# Altium schematic sheet Amplifier.SchDoc(U_Amplifier)
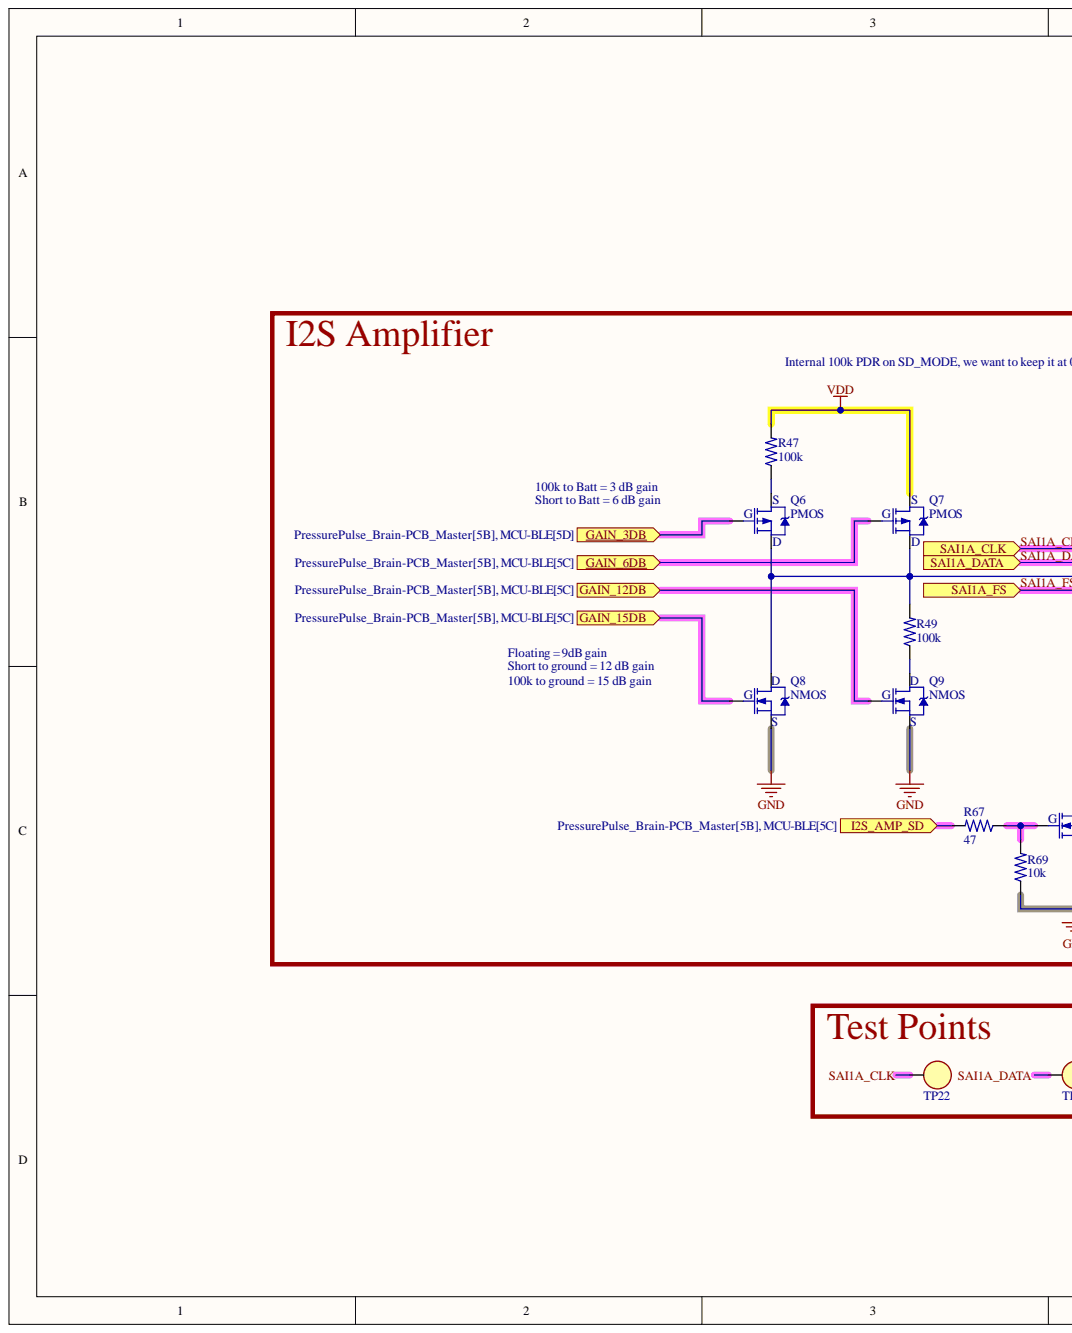
[diagram p0: Amplifier.SchDoc, left]
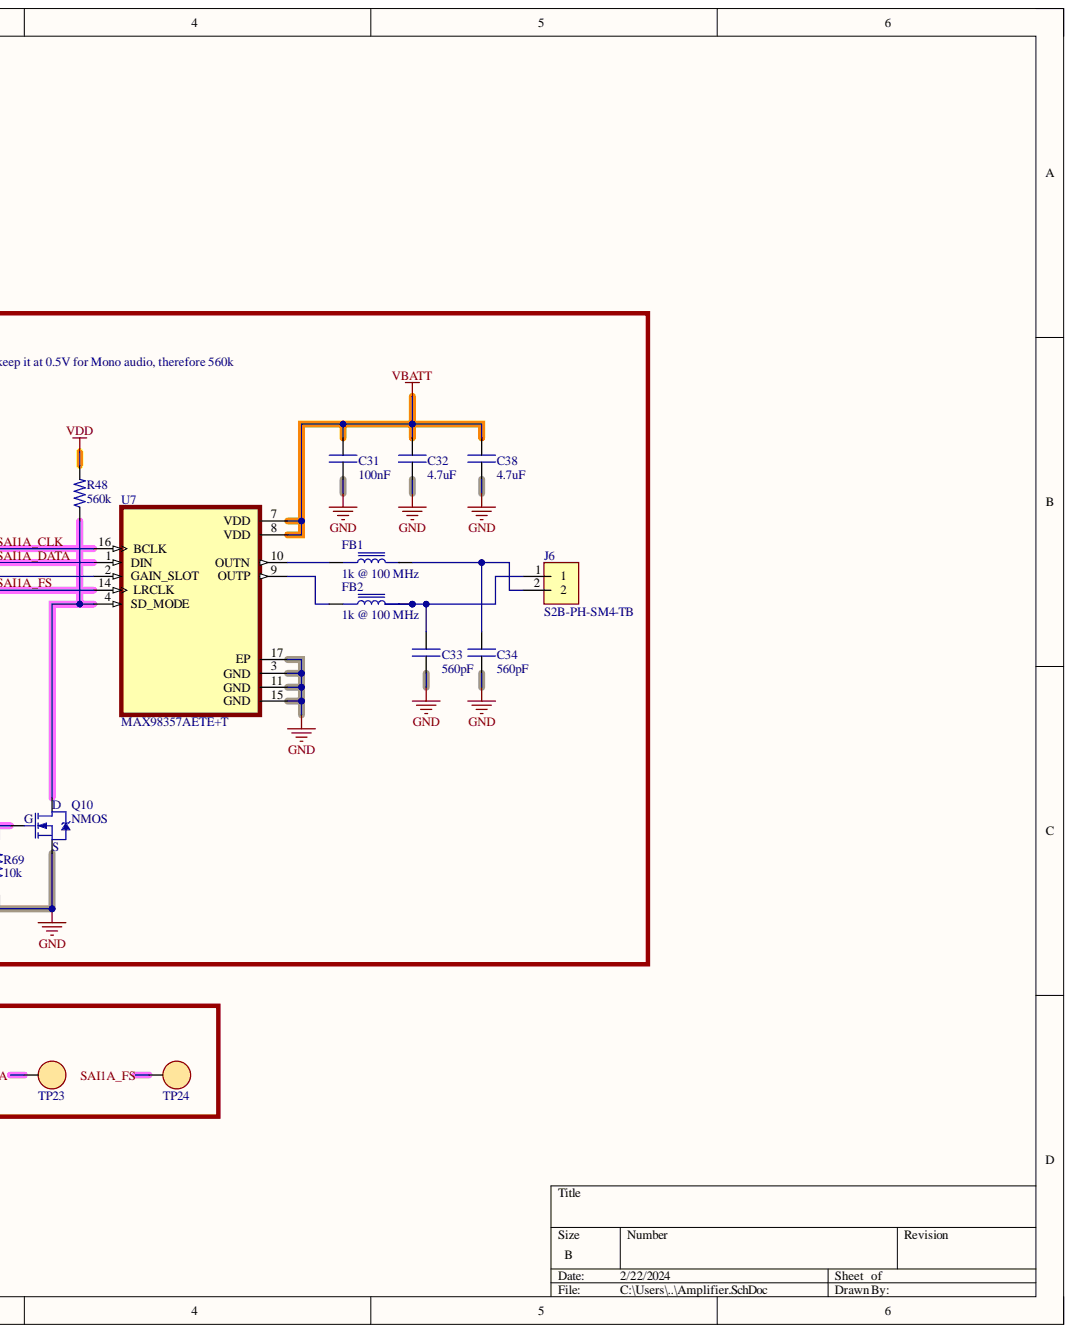
[diagram p1: Amplifier.SchDoc, right]

## Parts
C31  value 100nF  (hidden comment: CAP)
C32  value 4.7uF  (hidden comment: CAP)
C33  value 560pF  (hidden comment: CAP)
C34  value 560pF  (hidden comment: CAP)
C38  value 4.7uF  (hidden comment: CAP)
FB1  1k @ 100 MHz
FB2  1k @ 100 MHz
J6  S2B-PH-SM4-TB  [JST_PH-2POS-SMT-RA]
Q6  PMOS
Q7  PMOS
Q8  NMOS  [SOT-23]
Q9  NMOS  [SOT-23]
Q10  NMOS  [SOT-23]
R47  value 100k  (hidden comment: RES)
R48  value 560k  (hidden comment: RES)
R49  value 100k  (hidden comment: RES)
R67  value 47  [0402R]  (hidden comment: RES)
R69  value 10k  (hidden comment: RES)
TP22  RH-5019  [TP - RH-5019]  (value not drawn)
TP23  RH-5019  [TP - RH-5019]  (value not drawn)
TP24  RH-5019  [TP - RH-5019]  (value not drawn)
U7  MAX98357AETE+T

## Ports
GAIN_3DB, GAIN_6DB, GAIN_12DB, GAIN_15DB, I2S_AMP_SD, SAI1A_CLK, SAI1A_DATA, SAI1A_FS

## Nets
GND: C31.2 C32.1 C33.1 C34.1 C38.1 Q8.S Q9.S Q10.S R69.1 U7.3(GND) U7.11(GND) U7.15(GND) U7.17(EP)
VBATT: C31.1 C32.2 C38.2 U7.7(VDD) U7.8(VDD)
VDD: Q7.S R47.2 R48.2
GAIN_3DB: Q6.G ; port GAIN_3DB
GAIN_6DB: Q7.G ; port GAIN_6DB
GAIN_12DB: Q9.G ; port GAIN_12DB
GAIN_15DB: Q8.G ; port GAIN_15DB
I2S_AMP_SD: R67.2 ; port I2S_AMP_SD
SAI1A_CLK: TP22.1 U7.16(BCLK) ; port SAI1A_CLK
SAI1A_DATA: TP23.1 U7.1(DIN) ; port SAI1A_DATA
SAI1A_FS: TP24.1 U7.14(LRCLK) ; port SAI1A_FS
NetC33_2: C33.2 FB2.2 J6.1
NetC34_2: C34.2 FB1.2 J6.2
NetFB1_1: FB1.1 U7.10(OUTN)
NetFB2_1: FB2.1 U7.9(OUTP)
NetQ6_D: Q6.D Q7.D Q8.D R49.2 U7.2(GAIN_SLOT)
NetQ6_S: Q6.S R47.1
NetQ9_D: Q9.D R49.1
NetQ10_D: Q10.D R48.1 U7.4(SD_MODE)
NetQ10_G: Q10.G R67.1 R69.2

## Library data of the parts
C31-C34 C38 = CAP: Capacitor; Component Kind: Standard; Library Name: PressurePulse.SchLib
FB1 FB2 = FERRITE BEAD: MFR: TDK; MFR PN: MMZ1608Y102BTD25; Value: MMZ1608Y102BTD25; Component Kind: Standard; JLCPCB PN: C531293; Library Name: PressurePulse.SchLib
J6 = JST CONN 2POS PH: Connector Header Surface Mount, Right Angle 2 position 0.079 (2.00mm); MFR: JST Sales; MFR PN: S2B-PH-SM4-TB(TF)(SN); Value: S2B-PH-SM4-TB(TF)(SN); Component Kind: Standard; Library Name: PressurePulse.SchLib; Type: SMD
Q6 Q7 = PMOS: P-Channel MOSFET; MFR: Diodes Incorporated; Value: PPMT12V4; Component Kind: Standard; JLCPCB PN: C110724; Library Name: PressurePulse.SchLib
Q8-Q10 = NMOS: 100V 1.6A 1.3W 220mΩ@10V,1.6A N Channel SOT-23  MOSFETs ROHS; MFR: Infineon; MFR PN: IRLML0100TRPBF; Value: IRLML0100TRPBF; Component Kind: Standard; JLCPCB PN: C53658; Library Name: PressurePulse.SchLib
R47-R49 R67 R69 = RES: Resistor; Component Kind: Standard; Library Name: PressurePulse.SchLib
TP22-TP24 = TEST POINT: MFR: ronghe; Component Kind: Standard; JLCPCB PN: C5199800; Library Name: PressurePulse.SchLib; MFR PN: RH-5019; Value: RH-5019
U7 = MAX98357AETE+T: I2S Audio DAC and amp; MFR: Analog Devices; Component Kind: Standard; JLCPCB PN: C910544; Library Name: PressurePulse.SchLib; Type: SMD; Value: MAX98357AETE+T
